# Altium schematic sheet UVS-SCH-1.SchDoc
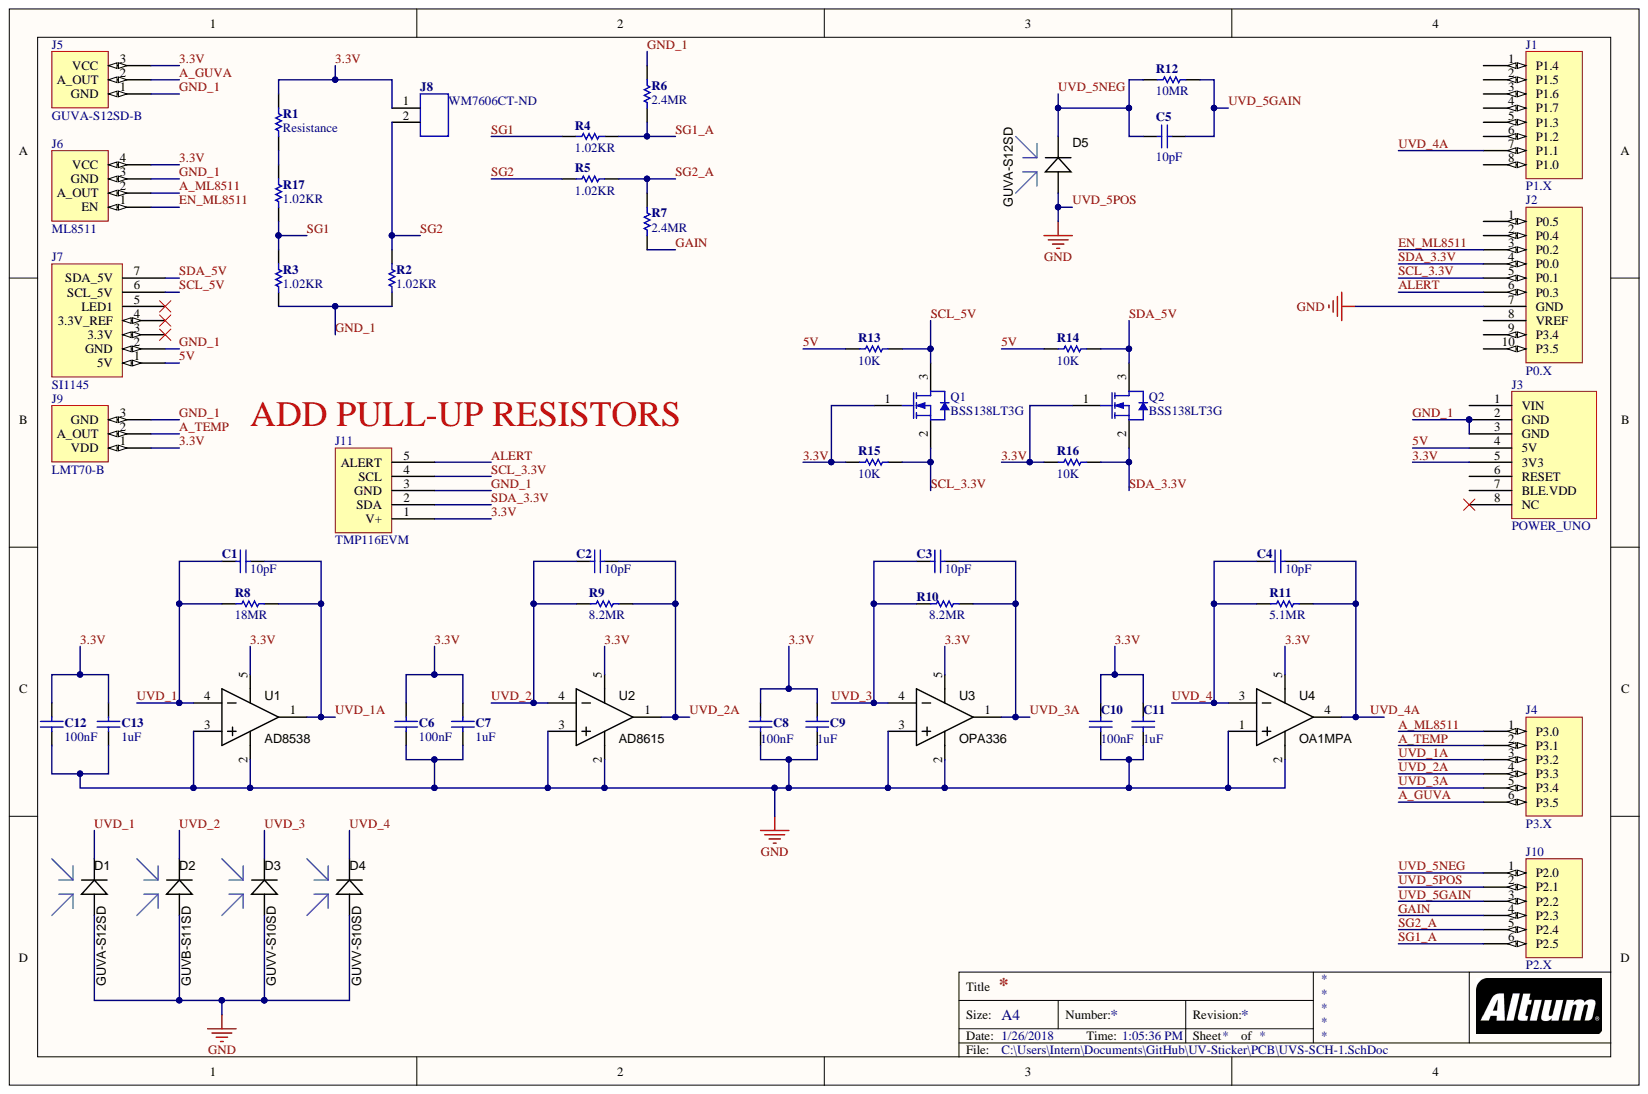
[diagram p0: UVS-SCH-1.SchDoc]

## Parts
C1  10pF  [CAP-0805(2012)-R]
C2  10pF  [CAP-0805(2012)-R]
C3  10pF  [CAP-0805(2012)-R]
C4  10pF  [CAP-0805(2012)-R]
C5  10pF  [CAP-0805(2012)-R]
C6  100nF  [CAP-0805(2012)-R]
C7  1uF  [CAP-0805(2012)-R]
C8  100nF  [CAP-0805(2012)-R]
C9  1uF  [CAP-0805(2012)-R]
C10  100nF  [CAP-0805(2012)-R]
C11  1uF  [CAP-0805(2012)-R]
C12  100nF  [CAP-0805(2012)-R]
C13  1uF  [CAP-0805(2012)-R]
D1  GUVA-S12SD  [SMD 3528]
D2  GUVB-S11SD  [SMD 3528]
D3  GUVV-S10SD  [SMD 3528]
D4  GUVV-S10SD  [SMD 3528]
D5  GUVA-S12SD  [SMD 3528]
J1  P1.X  [Header 8x1 8.5mm]
J2  P0.X  [Header 10x1 8.5mm]
J3  POWER_UNO  [Header 8x1 8.5mm]
J4  P3.X  [Header 6x1 8.5mm]
J5  GUVA-S12SD-B  [Header 3x1 8.5mm]
J6  ML8511  [Header 4x1 8.5mm]
J7  SI1145  [Header 7x1 8.5mm]
J8  Header 2 pin  [WM7606CT-ND]  (value not drawn)
J9  LMT70-B  [Header 3x1 8.5mm]
J10  P2.X  [Header 6x1 8.5mm]
J11  TMP116EVM  [Header 5x1 8.5mm]
Q1  BSS138LT3G  [ONSC-SOT-23-3-318-08_V]
Q2  BSS138LT3G  [ONSC-SOT-23-3-318-08_V]
R1  Resistance  [R-0805(2012)-R]
R2  1.02KR  [R-0805(2012)-R]
R3  1.02KR  [R-0805(2012)-R]
R4  1.02KR  [R-0805(2012)-R]
R5  1.02KR  [R-0805(2012)-R]
R6  2.4MR  [R-0805(2012)-R]
R7  2.4MR  [R-0805(2012)-R]
R8  18MR  [R-0805(2012)-R]
R9  8.2MR  [R-0805(2012)-R]
R10  8.2MR  [R-0805(2012)-R]
R11  5.1MR  [R-0805(2012)-R]
R12  10MR  [R-0805(2012)-R]
R13  10K  [R-0805(2012)-R]
R14  10K  [R-0805(2012)-R]
R15  10K  [R-0805(2012)-R]
R16  10K  [R-0805(2012)-R]
R17  1.02KR  [R-0805(2012)-R]
U1  AD8538  [SOT23-5]
U2  AD8615  [SOT23-5]
U3  OPA336  [SOT23-5]
U4  OA1MPA  [SC70-5L]

## Nets
3.3V: C6.1 C7.1 C8.1 C9.1 C10.1 C11.1 C12.1 C13.1 J3.5(3V3) J5.3 J6.4(VCC) J8.1 J9.1 J11.1(V+) Q1.1 Q2.1 R1.1 R15.1 R16.1 U1.5 U2.5 U3.5 U4.5
5V: J3.4(5V) J7.1(5V) R13.1 R14.1
A_GUVA: J4.6(P3.5) J5.2
A_ML8511: J4.1(P3.0) J6.2(A_OUT)
A_TEMP: J4.2(P3.1) J9.2
ALERT: J2.6(P0.3) J11.5(ALERT)
EN_ML8511: J2.3(P0.2) J6.1(EN)
GAIN: J10.4(P2.3) R7.2
GND_1: J3.2(GND) J3.3(GND) J5.1 J6.3(GND) J7.2(GND) J9.3 J11.3(GND) R2.2 R3.2 R6.1
SCL_3.3V: J2.5(P0.1) J11.4(SCL) Q1.2 R15.2
SCL_5V: J7.6(SCL_5V) Q1.3 R13.2
SDA_3.3V: J2.4(P0.0) J11.2(SDA) Q2.2 R16.2
SDA_5V: J7.7(SDA_5V) Q2.3 R14.2
SG1: R3.1 R4.1 R17.2
SG1_A: J10.6(P2.5) R4.2 R6.2
SG2: J8.2 R2.1 R5.1
SG2_A: J10.5(P2.4) R5.2 R7.1
UVD_1: C1.1 D1.K R8.1 U1.4
UVD_1A: C1.2 J4.3(P3.2) R8.2 U1.1
UVD_2: C2.1 D2.K R9.1 U2.4
UVD_2A: C2.2 J4.4(P3.3) R9.2 U2.1
UVD_3: C3.1 D3.K R10.1 U3.4
UVD_3A: C3.2 J4.5(P3.4) R10.2 U3.1
UVD_4: C4.1 D4.K R11.1 U4.3
UVD_4A: C4.2 J1.7(P1.1) R11.2 U4.4
UVD_5GAIN: C5.2 J10.3(P2.2) R12.2
UVD_5NEG: C5.1 D5.K J10.1(P2.0) R12.1
UVD_5POS: C6.2 C7.2 C8.2 C9.2 C10.2 C11.2 C12.2 C13.2 D1.A D2.A D3.A D4.A D5.A J2.7(GND) J10.2(P2.1) U1.2 U1.3 U2.2 U2.3 U3.2 U3.3 U4.1 U4.2
NetR1_2: R1.2 R17.1

Not connected: J1 1(P1.4) 2(P1.5) 3(P1.6) 4(P1.7) 5(P1.3) 6(P1.2) 8(P1.0); J2 1(P0.5) 2(P0.4) 8(VREF) 9(P3.4) 10(P3.5); J3 1(VIN) 6(RESET) 7(BLE.VDD) 8(NC); J7 3(3.3V) 4(3.3V_REF) 5(LED1)

## Library data of the parts
C1-C4 = C-0805(2012): ___ OHM ___ mW 0805(2012) SMD; Manufacturer: Kemet; Manufacturer Part Number: C0805C100J5GAC-TU; Dielectric: C0G; Dielectric Material: Ceramic; Dissipation Factor: 0.1%; Temperature Coefficient: 30ppm/°C; Tolerance: 5%; Voltage: 50V; Capacitance: 10pF; Case/Package: 0805; Case Code (Imperial): 0805; Case Code (Metric): 2012; Component Kind: Standard; ComponentLink1Description: https://datasheet.ciiva.com/144/docfinderpartnumber-c0805c100j5gactu-144802.pdf; ComponentLink1URL: https://datasheet.ciiva.com/144/docfinderpartnumber-c0805c100j5gactu-144802.pdf; ComponentLink2Description: https://datasheet.ciiva.com/6177/kem-c1003-c0g-smd-6177333.pdf; ComponentLink2URL: https://datasheet.ciiva.com/6177/kem-c1003-c0g-smd-6177333.pdf; ComponentLink3Description: https://datasheet.ciiva.com/28668/1789732-28668730.pdf; ComponentLink3URL: https://datasheet.ciiva.com/28668/1789732-28668730.pdf; ComponentLink4Description: https://datasheet.ciiva.com/5423/kem-c1003-c0g-smd-356956-5423483.pdf; ComponentLink4URL: https://datasheet.ciiva.com/5423/kem-c1003-c0g-smd-356956-5423483.pdf; ComponentLink5Description: https://datasheet.ciiva.com/14609/70095383-14609227.pdf; ComponentLink5URL: https://datasheet.ciiva.com/14609/70095383-14609227.pdf; ComponentLink6Description: https://datasheet.ciiva.com/2988/kem-c1003-c0g-smd-2988556.pdf; ComponentLink6URL: https://datasheet.ciiva.com/2988/kem-c1003-c0g-smd-2988556.pdf; ComponentLink7Description: https://datasheet.ciiva.com/7842/1725430-7842140.pdf; ComponentLink7URL: https://datasheet.ciiva.com/7842/1725430-7842140.pdf; ComponentLink8Description: https://datasheet.ciiva.com/7842/1725430-7842141.pdf; ComponentLink8URL: https://datasheet.ciiva.com/7842/1725430-7842141.pdf; Contact Material: Tin; Contact Plating: Tin; Depth: 1.25mm; Height: 0.78mm; Lead Free: Lead Free; Lead Pitch: 0.75mm; Length: 2mm; Max Operating Temperature: 125°C; Min Operating Temperature: -55°C; Mount: Surface Mount; Number of Pins: 2; Package Quantity: 1; Packaging: Tape and Reel; Pricing 1: 1=0.1, 10=0.04, 50=0.04, 100=0.028, 1000=0.019, 10000=0.012 (USD); Radiation Hardening: No; REACH SVHC: No SVHC; Stock 1: 118205; Supplier 1: Mouser; Supplier Part Number 1: 80-C0805C100J5G; Termination: SMD/SMT; Thickness: 0.035inch; Voltage Rating: 50V; Voltage Rating (DC): 50V; Width: 0.049inch; Library Name: UVS-Schlib.SchLib
C5 = C-0805(2012): ___ OHM ___ mW 0805(2012) SMD; Manufacturer: KEMET; Manufacturer Part Number: C0805C100J5GAC-TU; Dielectric: C0G; Dielectric Material: Ceramic; Dissipation Factor: 0.1%; Temperature Coefficient: 30ppm/°C; Tolerance: 5%; Voltage: 50V; Capacitance: 10pF; Case/Package: 0805; Case Code (Imperial): 0805; Case Code (Metric): 2012; Component Kind: Standard; ComponentLink1Description: https://datasheet.ciiva.com/144/docfinderpartnumber-c0805c100j5gactu-144802.pdf; ComponentLink1URL: https://datasheet.ciiva.com/144/docfinderpartnumber-c0805c100j5gactu-144802.pdf; ComponentLink2Description: https://datasheet.ciiva.com/6177/kem-c1003-c0g-smd-6177333.pdf; ComponentLink2URL: https://datasheet.ciiva.com/6177/kem-c1003-c0g-smd-6177333.pdf; ComponentLink3Description: https://datasheet.ciiva.com/28668/1789732-28668730.pdf; ComponentLink3URL: https://datasheet.ciiva.com/28668/1789732-28668730.pdf; ComponentLink4Description: https://datasheet.ciiva.com/5423/kem-c1003-c0g-smd-356956-5423483.pdf; ComponentLink4URL: https://datasheet.ciiva.com/5423/kem-c1003-c0g-smd-356956-5423483.pdf; ComponentLink5Description: https://datasheet.ciiva.com/14609/70095383-14609227.pdf; ComponentLink5URL: https://datasheet.ciiva.com/14609/70095383-14609227.pdf; ComponentLink6Description: https://datasheet.ciiva.com/2988/kem-c1003-c0g-smd-2988556.pdf; ComponentLink6URL: https://datasheet.ciiva.com/2988/kem-c1003-c0g-smd-2988556.pdf; ComponentLink7Description: https://datasheet.ciiva.com/7842/1725430-7842140.pdf; ComponentLink7URL: https://datasheet.ciiva.com/7842/1725430-7842140.pdf; ComponentLink8Description: https://datasheet.ciiva.com/7842/1725430-7842141.pdf; ComponentLink8URL: https://datasheet.ciiva.com/7842/1725430-7842141.pdf; Contact Material: Tin; Contact Plating: Tin; Depth: 1.25mm; Height: 0.78mm; Lead Free: Lead Free; Lead Pitch: 0.75mm; Length: 2mm; Max Operating Temperature: 125°C; Min Operating Temperature: -55°C; Mount: Surface Mount; Number of Pins: 2; Package Quantity: 1; Packaging: Tape and Reel; Pricing 1: 1=0.1, 10=0.04, 50=0.04, 100=0.028, 1000=0.019, 10000=0.012 (USD); Radiation Hardening: No; REACH SVHC: No SVHC; Stock 1: 113433; Supplier 1: Mouser; Supplier Part Number 1: 80-C0805C100J5G; Termination: SMD/SMT; Thickness: 0.035inch; Voltage Rating: 50V; Voltage Rating (DC): 50V; Width: 0.049inch; Library Name: UVS-Schlib.SchLib
C6 C8 C10 C12 = C-0805(2012): ___ OHM ___ mW 0805(2012) SMD; Manufacturer: Yageo; Manufacturer Part Number: CC0805KRX7R9BB104; Dielectric: X7R; Insulation Resistance: 100GR; Material: Ceramic; Tolerance: 10%; Voltage: 50V; Capacitance: 100nF; Case/Package: 0805; Case Code (Imperial): 0805; Case Code (Metric): 2012; Component Kind: Standard; ComponentLink1Description: https://datasheet.ciiva.com/11464/upy-gphc-x7r-6-3v-to-50v-8-11464757.pdf; ComponentLink1URL: https://datasheet.ciiva.com/11464/upy-gphc-x7r-6-3v-to-50v-8-11464757.pdf; ComponentLink2Description: https://datasheet.ciiva.com/28450/1489800-28450805.pdf; ComponentLink2URL: https://datasheet.ciiva.com/28450/1489800-28450805.pdf; ComponentLink3Description: https://datasheet.ciiva.com/155/mlccorderingcode-155929.pdf; ComponentLink3URL: https://datasheet.ciiva.com/155/mlccorderingcode-155929.pdf; ComponentLink4Description: https://datasheet.ciiva.com/11786/0900766b80d84f1d-11786009.pdf; ComponentLink4URL: https://datasheet.ciiva.com/11786/0900766b80d84f1d-11786009.pdf; ComponentLink5Description: https://datasheet.ciiva.com/155/mlccorderingcode-155930.pdf; ComponentLink5URL: https://datasheet.ciiva.com/155/mlccorderingcode-155930.pdf; ComponentLink6Description: https://datasheet.ciiva.com/155/mlccorderingcode-155931.pdf; ComponentLink6URL: https://datasheet.ciiva.com/155/mlccorderingcode-155931.pdf; ComponentLink7Description: https://datasheet.ciiva.com/46393/1734573-46393307.pdf; ComponentLink7URL: https://datasheet.ciiva.com/46393/1734573-46393307.pdf; ComponentLink8Description: https://datasheet.ciiva.com/16625/upy-gphc-x7r-6-3v-to-50v-8-16625549.pdf; ComponentLink8URL: https://datasheet.ciiva.com/16625/upy-gphc-x7r-6-3v-to-50v-8-16625549.pdf; ComponentLink9Description: https://datasheet.ciiva.com/29242/445210-da-01-en-kerko-chip-0805-x7r-0-1uf-10proz-50vg-29242074.pdf; ComponentLink9URL: https://datasheet.ciiva.com/29242/445210-da-01-en-kerko-chip-0805-x7r-0-1uf-10proz-50vg-29242074.pdf; Depth: 1.25mm; Halogen Free: Halogen Free; Height: 0.85mm; Lead Free: Lead Free; Length: 2mm; Max Operating Temperature: 125°C; Min Operating Temperature: -55°C; Mount: Surface Mount; Number of Pins: 2; Package Quantity: 1; Packaging: Tape and Reel; Pricing 1: 1=0.1, 10=0.037, 50=0.037, 100=0.017, 1000=0.013, 10000=0.009 (USD); Radiation Hardening: No; REACH SVHC: No SVHC; Stock 1: 432978; Supplier 1: Mouser; Supplier Part Number 1: 603-CC805KRX7R9BB104; Termination: SMD/SMT; Thickness: 0.033inch; Voltage Rating: 50V; Width: 0.049inch; Library Name: UVS-Schlib.SchLib
C7 C9 C11 C13 = C-0805(2012): ___ OHM ___ mW 0805(2012) SMD; Manufacturer: Yageo; Manufacturer Part Number: CC0805KKX7R7BB105; Dielectric: X7R; Tolerance: 10%; Voltage Rating: 16V; Capacitance: 1uF; Case/Package: 0805; Case Code (Imperial): 0805; Case Code (Metric): 2012; Component Kind: Standard; ComponentLink1Description: https://datasheet.ciiva.com/144/mlccorderingcode-144902.pdf; ComponentLink1URL: https://datasheet.ciiva.com/144/mlccorderingcode-144902.pdf; ComponentLink2Description: https://datasheet.ciiva.com/13173/upy-gp-np0-16v-to-50v-8-3714-13173254.pdf; ComponentLink2URL: https://datasheet.ciiva.com/13173/upy-gp-np0-16v-to-50v-8-3714-13173254.pdf; ComponentLink3Description: https://datasheet.ciiva.com/15189/getdatasheetpartid-251802-15189206.pdf; ComponentLink3URL: https://datasheet.ciiva.com/15189/getdatasheetpartid-251802-15189206.pdf; ComponentLink4Description: https://datasheet.ciiva.com/144/mlccorderingcode-144903.pdf; ComponentLink4URL: https://datasheet.ciiva.com/144/mlccorderingcode-144903.pdf; ComponentLink5Description: https://datasheet.ciiva.com/144/mlccorderingcode-144904.pdf; ComponentLink5URL: https://datasheet.ciiva.com/144/mlccorderingcode-144904.pdf; ComponentLink6Description: https://datasheet.ciiva.com/7978/1489800-7978201.pdf; ComponentLink6URL: https://datasheet.ciiva.com/7978/1489800-7978201.pdf; ComponentLink7Description: https://datasheet.ciiva.com/11464/upy-gphc-x7r-6-3v-to-50v-8-11464750.pdf; ComponentLink7URL: https://datasheet.ciiva.com/11464/upy-gphc-x7r-6-3v-to-50v-8-11464750.pdf; ComponentLink8Description: https://datasheet.ciiva.com/28450/1489800-28450750.pdf; ComponentLink8URL: https://datasheet.ciiva.com/28450/1489800-28450750.pdf; Depth: 1.25mm; Halogen Free: Halogen Free; Lead Free: Lead Free; Length: 2mm; Max Operating Temperature: 125°C; Min Operating Temperature: -55°C; Package Quantity: 1; Packaging: Tape and Reel; Pricing 1: 1=0.1, 10=0.049, 50=0.049, 100=0.022, 1000=0.017, 10000=0.011 (USD); Radiation Hardening: No; REACH SVHC: No SVHC; Stock 1: 127286; Supplier 1: Mouser; Supplier Part Number 1: 603-CC805KKX7R7BB105; Termination: SMD/SMT; Thickness: 0.049inch; Voltage Rating (DC): 16V; Width: 0.049inch; Library Name: UVS-Schlib.SchLib
D1 D5 = GUVA-S12SD: UV Photodiode, 3528 SMD; Component Kind: Standard; Library Name: UVS-Schlib.SchLib
D2 = GUVB-S11SD: UV Photodiode, 3528 SMD; Component Kind: Standard; Library Name: UVS-Schlib.SchLib
D3 D4 = GUVV-S10SD: UV Photodiode, 3528 SMD; Component Kind: Standard; Library Name: UVS-Schlib.SchLib
J1 = IOL: Component Kind: Standard; Library Name: UVS-Schlib.SchLib
J2 = IOH: Component Kind: Standard; Library Name: UVS-Schlib.SchLib
J4 = AD: Component Kind: Standard; Library Name: UVS-Schlib.SchLib
J5 = GUVA-S12SD-B: UV Light Sensor Breakout Board; Component Kind: Standard; Library Name: UVS-Schlib.SchLib
J6 = ML8511: UV Light Sensor Breakout Board; Component Kind: Standard; Library Name: UVS-Schlib.SchLib
J7 = SI1145: UV Light Sensor Digital; Component Kind: Standard; Library Name: UVS-Schlib.SchLib
J8 = CON-2Pin: Header, 2 pin, .1 pitch; Manufacturer: Molex, LLC; Manufacturer Part Number: 0533980271; Material Flammability Rating: UL94 V-0; Number of Positions: 2; Number of Positions Loaded: All; Pitch - Mating: 0.049" (1.25mm); Shrouding: Shrouded - 3 Wall; Style: Board to Cable/Wire; Applications: Automotive, General Purpose, Industrial, Medical; Category: Connectors, Interconnects; Component Kind: Standard; ComponentLink1Description: http://www.molex.com/pdm_docs/sd/533980271_sd.pdf; ComponentLink1URL: http://www.molex.com/pdm_docs/sd/533980271_sd.pdf; Connector Type: Header; Contact Finish - Mating: Tin; Contact Finish - Post: Tin; Contact Finish Thickness - Mating: 39µin (1.00µm); Contact Finish Thickness - Post: -; Contact Length - Mating: -; Contact Length - Post: -; Contact Material: Phosphor Bronze; Contact Shape: Rectangular; Contact Type: Male Blade; Current Rating: -; Fastening Type: Detent Lock; Features: Solder Retention; Ingress Protection: -; Insulation Color: Natural; Insulation Height: 0.185" (4.70mm); Insulation Material: Polyamide (PA), Nylon; Mated Stacking Heights: -; Mounting Type: Surface Mount; Number of Rows: 1; Operating Temperature: -; Overall Contact Length: -; Packaging: Cut Tape (CT); Part Status: Active; Pricing 1: 1=1.07, 10=0.941, 25=0.886, 50=0.849, 100=0.8122, 250=0.73832, 500=0.66448 (USD); RoHS: RoHS Compliant; Row Spacing - Mating: -; Stock 1: 38010; Supplier 1: Digi-Key; Supplier Part Number 1: WM7606CT-ND; Termination: Solder; Voltage Rating: -; Library Name: UVS-Schlib.SchLib
J9 = LMT70-B: Precision Analog Temperature Sensor; Component Kind: Standard; Library Name: UVS-Schlib.SchLib
Q1 Q2 = CMP-1058-00765-1: Power MOSFET, 200 mA, 50 V, N-Channel, 3-Pin SOT-23, Pb-Free, Tape and Reel; Manufacturer: ON Semiconductor; Continuous Drain Current (ID): 200mA; Drain to Source Breakdown Voltage: 50V; Drain to Source Resistance: 3.5R; Element Configuration: Single; Gate to Source Voltage (Vgs): 20V; Input Capacitance: 50pF; Max Power Dissipation: 225mW; Nominal Vgs: 500mV; Number of Elements: 1; Turn-Off Delay Time: 20ns; Case/Package: SOT-23-3; Component Kind: Standard; ComponentLink1Description: https://datasheet.ciiva.com/936/bss138lt1-d-936790.pdf; ComponentLink1URL: https://datasheet.ciiva.com/936/bss138lt1-d-936790.pdf; ComponentLink2Description: https://datasheet.ciiva.com/936/bss138lt1-d-936791.pdf; ComponentLink2URL: https://datasheet.ciiva.com/936/bss138lt1-d-936791.pdf; ComponentLink3Description: https://datasheet.ciiva.com/1190/1596366-1190138.pdf; ComponentLink3URL: https://datasheet.ciiva.com/1190/1596366-1190138.pdf; ComponentLink4Description: https://datasheet.ciiva.com/8059/bss138lt1-d-8059434.pdf; ComponentLink4URL: https://datasheet.ciiva.com/8059/bss138lt1-d-8059434.pdf; ComponentLink5Description: https://datasheet.ciiva.com/11164/bss138lt1-d-11164859.pdf; ComponentLink5URL: https://datasheet.ciiva.com/11164/bss138lt1-d-11164859.pdf; ComponentLink6Description: https://datasheet.ciiva.com/15198/getdatasheetpartid-141721-15198909.pdf; ComponentLink6URL: https://datasheet.ciiva.com/15198/getdatasheetpartid-141721-15198909.pdf; ComponentLink7Description: https://datasheet.ciiva.com/16449/bss138lt1-d-16449517.pdf; ComponentLink7URL: https://datasheet.ciiva.com/16449/bss138lt1-d-16449517.pdf; Current Rating: 200mA; Drain to Source Voltage (Vdss): 50V; Halogen Free: Halogen Free; Height: 0.94mm; Lead Free: Lead Free; Length: 2.9mm; Library Name: Altium Content Vault; Manufacturer Part Number: BSS138LT3G; Max Operating Temperature: 150°C; Min Operating Temperature: -55°C; Number of Pins: 3; Package Quantity: 1; Packaging: Tape and Reel; Power Dissipation: 225mW; Pricing 1: 1=0.25, 10=0.167, 50=0.167, 100=0.07, 1000=0.048, 10000=0.032 (USD); Radiation Hardening: No; Rds On Max: 3.5R; REACH SVHC: No SVHC; Stock 1: 150086; Supplier 1: Mouser; Supplier Part Number 1: 863-BSS138LT3G; Threshold Voltage: 500mV; Turn-On Delay Time: 20ns; Voltage Rating (DC): 50V; Width: 1.3mm
R1 = R-0805(2012): ___ OHM ___ mW 0805(2012) SMD; Component Kind: Standard; Library Name: UVS-Schlib.SchLib
R2-R5 R17 = R-0805(2012): ___ OHM ___ mW 0805(2012) SMD; Manufacturer: Panasonic; Manufacturer Part Number: ERA6AEB1021V; Max Power Dissipation: 125mW; Temperature Coefficient: 25ppm/°C; Tolerance: 0.1%; Voltage Rating: 200V; Voltage Rating (DC): 100V; Case/Package: 0805; Case Code (Imperial): 0805; Case Code (Metric): 2012; Component Kind: Standard; ComponentLink1Description: https://datasheet.ciiva.com/5870/485442-5870581.pdf; ComponentLink1URL: https://datasheet.ciiva.com/5870/485442-5870581.pdf; ComponentLink2URL: https://datasheet.ciiva.com/5870/485442-5870582.pdf; ComponentLink3Description: https://datasheet.ciiva.com/24072/aoa0000ce26-197354-24072246.pdf; ComponentLink3URL: https://datasheet.ciiva.com/24072/aoa0000ce26-197354-24072246.pdf; ComponentLink4Description: https://datasheet.ciiva.com/30091/54aoa0000ce26-30091290.pdf; ComponentLink4URL: https://datasheet.ciiva.com/30091/54aoa0000ce26-30091290.pdf; ComponentLink5Description: https://datasheet.ciiva.com/7911/485442-7911579.pdf; ComponentLink5URL: https://datasheet.ciiva.com/7911/485442-7911579.pdf; ComponentLink6Description: https://datasheet.ciiva.com/9198/aoa0000ce26-9198827.pdf; ComponentLink6URL: https://datasheet.ciiva.com/9198/aoa0000ce26-9198827.pdf; ComponentLink7Description: https://datasheet.ciiva.com/7911/485442-7911580.pdf; ComponentLink7URL: https://datasheet.ciiva.com/7911/485442-7911580.pdf; ComponentLink8Description: https://datasheet.ciiva.com/11810/0900766b80eb1d8c-11810140.pdf; ComponentLink8URL: https://datasheet.ciiva.com/11810/0900766b80eb1d8c-11810140.pdf; ComponentLink9Description: https://datasheet.ciiva.com/17215/aoa0000ce26-17215164.pdf; ComponentLink9URL: https://datasheet.ciiva.com/17215/aoa0000ce26-17215164.pdf; ComponentLink10URL: https://datasheet.ciiva.com/26335/459032-da-01-en-widerstand-0805-era-26335793.pdf; Composition: Metal Film,Thin Film; Contact Plating: Tin; Depth: 1.25mm; Height: 0.5mm; Lead Free: Lead Free; Length: 2mm; Max Operating Temperature: 155°C; Min Operating Temperature: -55°C; Mount: Surface Mount; Number of Pins: 2; Number of Terminations: 2; Package Quantity: 1; Packaging: Tape and Reel; Power Rating: 125mW; Pricing 1: 1=0.63, 10=0.249, 50=0.249, 100=0.149, 1000=0.076, 10000=0.039 (USD); Radiation Hardening: No; REACH SVHC: No SVHC; Resistance: 1.02KR; Stock 1: 5493; Supplier 1: Mouser; Supplier Part Number 1: 667-ERA-6AEB1021V; Termination: SMD/SMT; Width: 1.25mm; Library Name: UVS-Schlib.SchLib
  R2-R4 R17: ComponentLink2Description: https://datasheet.ciiva.com/5870/485442-5870582.pdf; ComponentLink10Description: https://datasheet.ciiva.com/26335/459032-da-01-en-widerstand-0805-era-26335793.pdf
R6 R7 = R-0805(2012): ___ OHM ___ mW 0805(2012) SMD; Manufacturer: Panasonic ECG; Manufacturer Part Number: ERJ-6GEYJ245V; Max Power Dissipation: 125mW; Temperature Coefficient: 150ppm/°C; Tolerance: 5%; Voltage Rating: 150V; Case/Package: 0805; Case Code (Imperial): 0805; Case Code (Metric): 2012; Component Kind: Standard; ComponentLink1Description: https://datasheet.ciiva.com/9197/aoa0000ce1-9197110.pdf; ComponentLink1URL: https://datasheet.ciiva.com/9197/aoa0000ce1-9197110.pdf; ComponentLink2Description: https://datasheet.ciiva.com/46378/1678796-46378639.pdf; ComponentLink2URL: https://datasheet.ciiva.com/46378/1678796-46378639.pdf; Composition: Thick Film; Contact Plating: Tin; Depth: 1.25mm; Height: 0.028inch; Lead Free: Lead Free; Length: 2mm; Max Operating Temperature: 155°C; Military Standard: Not; Min Operating Temperature: -55°C; Mount: Surface Mount; Number of Terminations: 2; Package Quantity: 1; Packaging: Tape and Reel; Power Rating: 125mW; Pricing 1: 1=0.1, 10=0.015, 50=0.015, 100=0.006, 1000=0.004, 10000=0.002 (USD); REACH SVHC: No SVHC; Resistance: 2.4MR; Stock 1: 75536; Supplier 1: Mouser; Supplier Part Number 1: 667-ERJ-6GEYJ245V; Termination: SMD/SMT; Voltage Rating (DC): 150V; Width: 1.25mm; Library Name: UVS-Schlib.SchLib
R8 = R-0805(2012): ___ OHM ___ mW 0805(2012) SMD; Manufacturer: Yageo; Manufacturer Part Number: RC0805JR-0718ML; Max Power Dissipation: 125mW; Temperature Coefficient: 200ppm/°C; Tolerance: 5%; Voltage Rating: 150V; Case/Package: 0805; Case Code (Imperial): 0805; Case Code (Metric): 2012; Component Kind: Standard; ComponentLink1Description: https://datasheet.ciiva.com/7941/442941-7941901.pdf; ComponentLink1URL: https://datasheet.ciiva.com/7941/442941-7941901.pdf; ComponentLink2Description: https://datasheet.ciiva.com/7941/442941-7941902.pdf; ComponentLink2URL: https://datasheet.ciiva.com/7941/442941-7941902.pdf; Composition: Thick Film; Depth: 1.25mm; Height: 0.024inch; Length: 2mm; Max Operating Temperature: 155°C; Military Standard: Not; Min Operating Temperature: -55°C; Number of Terminations: 2; Packaging: Tape and Reel; Power Rating: 125mW; Pricing 1: 1=0.19, 10=0.045, 50=0.045, 100=0.015, 1000=0.011, 10000=0.006 (USD); REACH SVHC: Unknown; Resistance: 18MR; Stock 1: 23485; Supplier 1: Mouser; Supplier Part Number 1: 603-RC0805JR-0718ML; Termination: SMD/SMT; Width: 1.25mm; Library Name: UVS-Schlib.SchLib
R9 R10 = R-0805(2012): ___ OHM ___ mW 0805(2012) SMD; Manufacturer: Yageo; Manufacturer Part Number: RC0805FR-078M2L; Max Power Dissipation: 125mW; Temperature Coefficient: 100ppm/°C; Tolerance: 1%; Voltage Rating: 150V; Case/Package: 0805; Case Code (Imperial): 0805; Case Code (Metric): 2012; Component Kind: Standard; ComponentLink1Description: https://datasheet.ciiva.com/6135/444164-6135430.pdf; ComponentLink1URL: https://datasheet.ciiva.com/6135/444164-6135430.pdf; ComponentLink2Description: https://datasheet.ciiva.com/7941/444164-7941849.pdf; ComponentLink2URL: https://datasheet.ciiva.com/7941/444164-7941849.pdf; ComponentLink3Description: https://datasheet.ciiva.com/7941/444164-7941850.pdf; ComponentLink3URL: https://datasheet.ciiva.com/7941/444164-7941850.pdf; ComponentLink4Description: https://datasheet.ciiva.com/6135/444164-6135422.pdf; ComponentLink4URL: https://datasheet.ciiva.com/6135/444164-6135422.pdf; Composition: Thick Film; Height: 0.024inch; Length: 0.079inch; Max Operating Temperature: 155°C; Min Operating Temperature: -55°C; Number of Pins: 2; Number of Terminations: 2; Packaging: Tape and Reel; Power Rating: 125mW; Pricing 1: 1=0.1, 10=0.015, 50=0.015, 100=0.005, 1000=0.004, 10000=0.002 (USD); REACH SVHC: No SVHC; Resistance: 8.2MR; Stock 1: 52831; Supplier 1: Mouser; Supplier Part Number 1: 603-RC0805FR-078M2L; Termination: SMD/SMT; Voltage Rating (DC): 150V; Width: 1.25mm; Library Name: UVS-Schlib.SchLib
R11 = R-0805(2012): ___ OHM ___ mW 0805(2012) SMD; Manufacturer: Yageo; Manufacturer Part Number: RC0805FR-075M1L; Max Power Dissipation: 125mW; Temperature Coefficient: 100ppm/°C; Tolerance: 1%; Voltage Rating: 150V; Case/Package: 0805; Case Code (Imperial): 0805; Case Code (Metric): 2012; Component Kind: Standard; ComponentLink1Description: http://www.yageo.ru/pdf/RC0805.pdf; ComponentLink1URL: http://www.yageo.ru/pdf/RC0805.pdf; Composition: Thick Film; Height: 0.024inch; Lead Free: Lead Free; Length: 0.079inch; Max Operating Temperature: 155°C; Min Operating Temperature: -55°C; Number of Terminations: 2; Packaging: Tape and Reel; Power Rating: 125mW; Pricing 1: 1=0.1, 10=0.015, 50=0.015, 100=0.005, 1000=0.004, 10000=0.002 (USD); Resistance: 5.1MR; Stock 1: 45922; Supplier 1: Mouser; Supplier Part Number 1: 603-RC0805FR-075M1L; Termination: SMD/SMT; Width: 0.049inch; Library Name: UVS-Schlib.SchLib
R12 = R-0805(2012): ___ OHM ___ mW 0805(2012) SMD; Manufacturer: Yageo; Manufacturer Part Number: RC0805JR-0710ML; Max Power Dissipation: 125mW; Temperature Coefficient: 100ppm/°C; Tolerance: 5%; Voltage Rating: 150V; Case/Package: 0805; Case Code (Imperial): 0805; Case Code (Metric): 2012; Component Kind: Standard; ComponentLink1Description: https://datasheet.ciiva.com/12667/rc0805-12667352.pdf; ComponentLink1URL: https://datasheet.ciiva.com/12667/rc0805-12667352.pdf; ComponentLink2Description: https://datasheet.ciiva.com/2456/pyu-r-int-thick-7-2456140.pdf; ComponentLink2URL: https://datasheet.ciiva.com/2456/pyu-r-int-thick-7-2456140.pdf; ComponentLink3Description: https://datasheet.ciiva.com/2456/pyu-r-int-thick-7-2456141.pdf; ComponentLink3URL: https://datasheet.ciiva.com/2456/pyu-r-int-thick-7-2456141.pdf; ComponentLink4Description: https://datasheet.ciiva.com/2456/pyu-r-int-thick-7-2456142.pdf; ComponentLink4URL: https://datasheet.ciiva.com/2456/pyu-r-int-thick-7-2456142.pdf; Composition: Thick Film; Contact Plating: Tin,Lead; Depth: 1.25mm; Height: 0.024inch; Lead Free: Lead Free; Length: 0.079inch; Max Operating Temperature: 155°C; Military Standard: Not; Min Operating Temperature: -55°C; Number of Terminations: 2; Package Quantity: 1; Packaging: Tape and Reel; Power Rating: 125mW; Pricing 1: 1=0.1, 10=0.013, 50=0.013, 100=0.005, 1000=0.003, 10000=0.002 (USD); Radiation Hardening: No; REACH SVHC: Unknown; Resistance: 10MR; Stock 1: 47973; Supplier 1: Mouser; Supplier Part Number 1: 603-RC0805JR-0710ML; Termination: SMD/SMT; Width: 0.049inch; Library Name: UVS-Schlib.SchLib
R13-R16 = R-0805(2012): ___ OHM ___ mW 0805(2012) SMD; Manufacturer: Yageo; Manufacturer Part Number: RC0805FR-0710KL; Max Power Dissipation: 125mW; Temperature Coefficient: 100ppm/°C; Tolerance: 1%; Voltage Rating: 150V; Case/Package: 0805; Case Code (Imperial): 0805; Case Code (Metric): 2012; Component Kind: Standard; ComponentLink1Description: https://datasheet.ciiva.com/11808/0900766b8106beab-11808151.pdf; ComponentLink1URL: https://datasheet.ciiva.com/11808/0900766b8106beab-11808151.pdf; ComponentLink2Description: https://datasheet.ciiva.com/12669/rc0805-12669688.pdf; ComponentLink2URL: https://datasheet.ciiva.com/12669/rc0805-12669688.pdf; ComponentLink3Description: https://datasheet.ciiva.com/46447/444164-46447563.pdf; ComponentLink3URL: https://datasheet.ciiva.com/46447/444164-46447563.pdf; ComponentLink4Description: https://datasheet.ciiva.com/2456/pyu-r-int-thick-7-2456972.pdf; ComponentLink4URL: https://datasheet.ciiva.com/2456/pyu-r-int-thick-7-2456972.pdf; ComponentLink5Description: https://datasheet.ciiva.com/2456/pyu-r-int-thick-7-2456973.pdf; ComponentLink5URL: https://datasheet.ciiva.com/2456/pyu-r-int-thick-7-2456973.pdf; ComponentLink6Description: https://datasheet.ciiva.com/2456/pyu-r-int-thick-7-2456974.pdf; ComponentLink6URL: https://datasheet.ciiva.com/2456/pyu-r-int-thick-7-2456974.pdf; ComponentLink7Description: https://datasheet.ciiva.com/16747/rc0805-16747087.pdf; ComponentLink7URL: https://datasheet.ciiva.com/16747/rc0805-16747087.pdf; Composition: Thick Film; Depth: 1.25mm; Height: 0.024inch; Lead Free: Lead Free; Length: 2mm; Max Operating Temperature: 155°C; Military Standard: Not; Min Operating Temperature: -55°C; Number of Terminations: 2; Package Quantity: 1; Packaging: Tape and Reel; Power Rating: 125mW; Pricing 1: 1=0.1, 10=0.016, 50=0.016, 100=0.006, 1000=0.004, 10000=0.003 (USD); REACH SVHC: Unknown; Resistance: 10K; Stock 1: 1821555; Supplier 1: Mouser; Supplier Part Number 1: 603-RC0805FR-0710KL; Termination: SMD/SMT; Width: 0.049inch; Library Name: UVS-Schlib.SchLib
U1 = AD8538: IC SMD Atuo-Zero Op Amp Precision, Low Power; Manufacturer Part Number: AD8538AUJZ-REEL7; Brand: Analog Devices; CMRR - Common Mode Rejection Ratio: 150 dB; en - Input Voltage Noise Density: 50 nV/sqrt Hz at 5 V; GBP - Gain Bandwidth Product: 430 kHz; Ib - Input Bias Current: 25 pA; Maximum Dual Supply Voltage: +/- 2.5 V; Minimum Dual Supply Voltage: +/- 1.35 V; Number of Channels: 1 Channel; Operating Supply Current: 180 uA; Operating Supply Voltage: 2.7 V to 5.5 V; PSRR - Power Supply Rejection Ratio: 125 dB; Shutdown: No Shutdown; SR - Slew Rate: 0.4 V/us at 5 V; Supply Voltage - Max: 5.5 V; Supply Voltage - Min: 2.7 V; Voltage Gain dB: 141 dB; Vos - Input Offset Voltage: 5 uV; Category: Precision Amplifiers; Component Kind: Standard; ComponentLink1Description: http://www.mouser.com/ds/2/609/AD8538_8539-877837.pdf; ComponentLink1URL: http://www.mouser.com/ds/2/609/AD8538_8539-877837.pdf; Height: 0.87 mm; Length: 2.9 mm; Manufacturer: Analog Devices; Maximum Operating Temperature: + 125 C; Minimum Operating Temperature: - 40 C; Minimum Order Quantity: 1; Mounting Style: SMD/SMT; Package / Case: TSOT-5; Packaging: Reel; Pricing 1: 1=1.39, 10=1.26, 25=1.12, 100=1.01, 250=0.900, 500=0.788, 1000=0.652, 3000=0.606, 6000=0.601 (USD); Product: Precision Amplifiers; Reeling: True; RoHS: RoHS Compliant; RoHS - Mouser: Y; Series: AD8538; Standard Pack Qty: 3000; Stock 1: 8750; Supplier 1: Mouser; Supplier Part Number 1: 584-AD8538AUJZ-R7; Type: General Purpose Amplifier; Width: 1.6 mm; Library Name: UVS-Schlib.SchLib
U2 = AD8615: IC SMD Transimpedance Op Amp Precision; Manufacturer Part Number: AD8615AUJZ-REEL7; Brand: Analog Devices; CMRR - Common Mode Rejection Ratio: 100 dB; en - Input Voltage Noise Density: 10 nV/sqrt Hz at 5 V; GBP - Gain Bandwidth Product: 24 MHz; Ib - Input Bias Current: 550 pA; Input Voltage Range - Max: 5 V; Number of Channels: 1 Channel; Operating Supply Current: 1.7 mA; Operating Supply Voltage: 2.7 V to 5 V; Output Current per Channel: 150 mA; Output Type: Rail to Rail Input/Output; PSRR - Power Supply Rejection Ratio: 90 dB; Shutdown: No Shutdown; SR - Slew Rate: 12 V/us; Supply Voltage - Min: 2.7 V; Voltage Gain dB: 123.52 dB; Vos - Input Offset Voltage: 80 uV; Category: Precision Amplifiers; Component Kind: Standard; ComponentLink1Description: http://www.mouser.com/ds/2/609/AD8615_8616_8618-877841.pdf; ComponentLink1URL: http://www.mouser.com/ds/2/609/AD8615_8616_8618-877841.pdf; Height: 0.87 mm; Length: 2.9 mm; Manufacturer: Analog Devices; Maximum Operating Temperature: + 125 C; Minimum Operating Temperature: - 40 C; Minimum Order Quantity: 1; Mounting Style: SMD/SMT; Package / Case: TSOT-5; Packaging: Reel; Pricing 1: 1=2.11, 10=1.91, 25=1.71, 100=1.53, 250=1.36, 500=1.19, 1000=0.992, 3000=0.921, 6000=0.913 (USD); Product: Precision Amplifiers; Reeling: True; RoHS: RoHS Compliant; RoHS - Mouser: Y; Series: AD8615; Standard Pack Qty: 3000; Stock 1: 7346; Supplier 1: Mouser; Supplier Part Number 1: 584-AD8615AUJZ-R7; Supply Voltage - Max: 5 V; Type: General Purpose Amplifier; Width: 1.6 mm; Library Name: UVS-Schlib.SchLib
U3 = OPA336: Manufacturer Part Number: OPA336NA/3K; Amplifier Type: Low Power Amplifier; Brand: Texas Instruments; CMRR - Common Mode Rejection Ratio: 76 dB to 86 dB; en - Input Voltage Noise Density: 40 nV/sqrt Hz; GBP - Gain Bandwidth Product: 100 kHz; Ib - Input Bias Current: 10 pA; In - Input Noise Current Density: 30 fA/sqrt Hz; Input Type: Rail-to-Rail; Number of Channels: 1 Channel; Operating Supply Current: 20 uA; Operating Supply Voltage: 2.3 V to 5.5 V; PSRR - Power Supply Rejection Ratio: 25 uV/V; Shutdown: No Shutdown; SR - Slew Rate: 0.03 V/us; Supply Type: Single; Supply Voltage - Max: 5.5 V; Supply Voltage - Min: 2.3 V; Voltage Gain dB: 115 dB; Vos - Input Offset Voltage: 0.125 mV; Category: Operational Amplifiers - Op Amps; Component Kind: Standard; Height: 1.15 mm; Ios - Input Offset Current: 10 pA; Length: 2.9 mm; Manufacturer: Texas Instruments; Maximum Operating Temperature: + 85 C; Minimum Operating Temperature: - 40 C; Minimum Order Quantity: 1; Mounting Style: SMD/SMT; Output Type: Rail-to-Rail; Package / Case: SOT-23-5; Packaging: Reel; Pricing 1: 1=1.72, 10=1.55, 25=1.47, 100=1.24, 250=1.17, 500=1.02, 1000=0.731, 3000=0.731 (USD); Product: Precision Amplifiers; Reeling: True; RoHS: RoHS Compliant; RoHS - Mouser: Y; Series: OPA336; Standard Pack Qty: 3000; Stock 1: 11756; Supplier 1: Mouser; Supplier Part Number 1: 595-OPA336NA/3K; Technology: CMOS; Width: 1.6 mm; Library Name: UVS-Schlib.SchLib
U4 = OA1MPA: Manufacturer: STMicroelectronics; Manufacturer Part Number: OA1MPA22C; Bandwidth: 150KHz; Input Bias Current: 1pA; Input Offset Voltage (Vos): 200uV; Number of Amplifiers: 1; Operating Supply Current: 10uA; Output Current per Channel: 56mA; Slew Rate: 0.06V/µs; Supply Voltage: 5.5V; Case/Package: SC; Component Kind: Standard; ComponentLink1Description: https://datasheet.ciiva.com/29638/dm00109015-29638347.pdf; Gain Bandwidth Product: 150KHz; Height: 1mm; Lead Free: Lead Free; Length: 2.2mm; Max Operating Temperature: 125°C; Min Operating Temperature: -40°C; Number of Circuits: 1; Number of Pins: 5; Package Quantity: 3000; Packaging: Tape and Reel; Pricing 1: 1=1.27, 10=1.08, 50=1.08, 100=0.832, 1000=0.58, 10000=0.494 (USD); REACH SVHC: No SVHC; Stock 1: 242; Supplier 1: Mouser; Supplier Part Number 1: 511-OA1MPA22C; Width: 1.35mm; Library Name: UVS-Schlib.SchLib
J3 = POWER_UNO: Component Kind: Standard; Library Name: UVS-Schlib.SchLib
J10 = P2.X: Component Kind: Standard; Library Name: UVS-Schlib.SchLib
J11 = TMP116EVM: Component Kind: Standard; Library Name: UVS-Schlib.SchLib
